# Altium schematic sheet SCM20260D-Dimm.SchDoc(SCM20260D-Dimm)
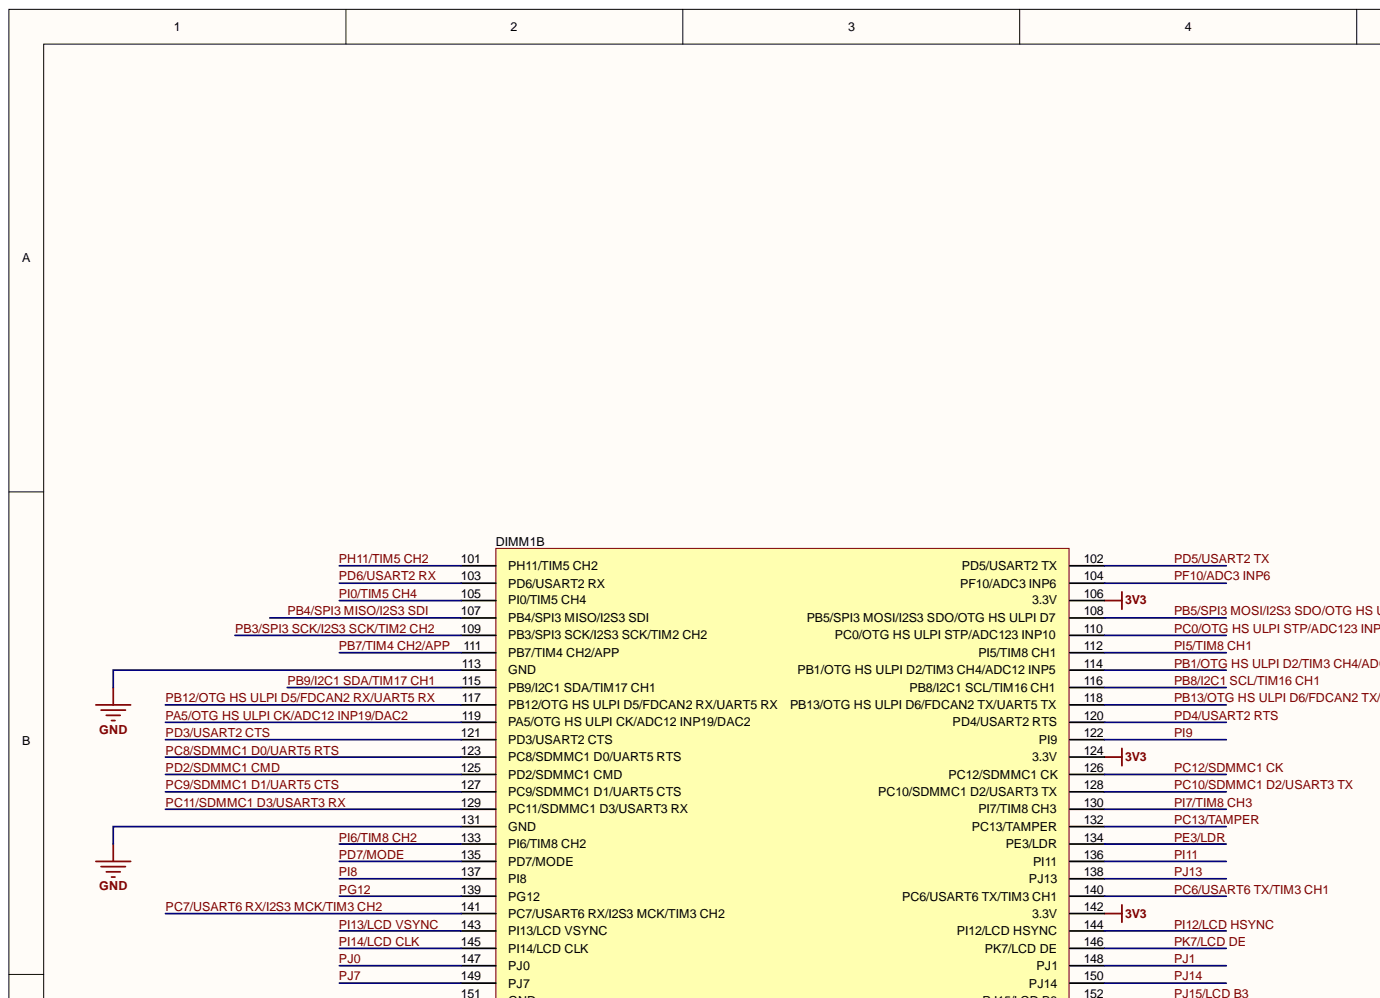
[diagram p0: SCM20260D-Dimm.SchDoc, top left]
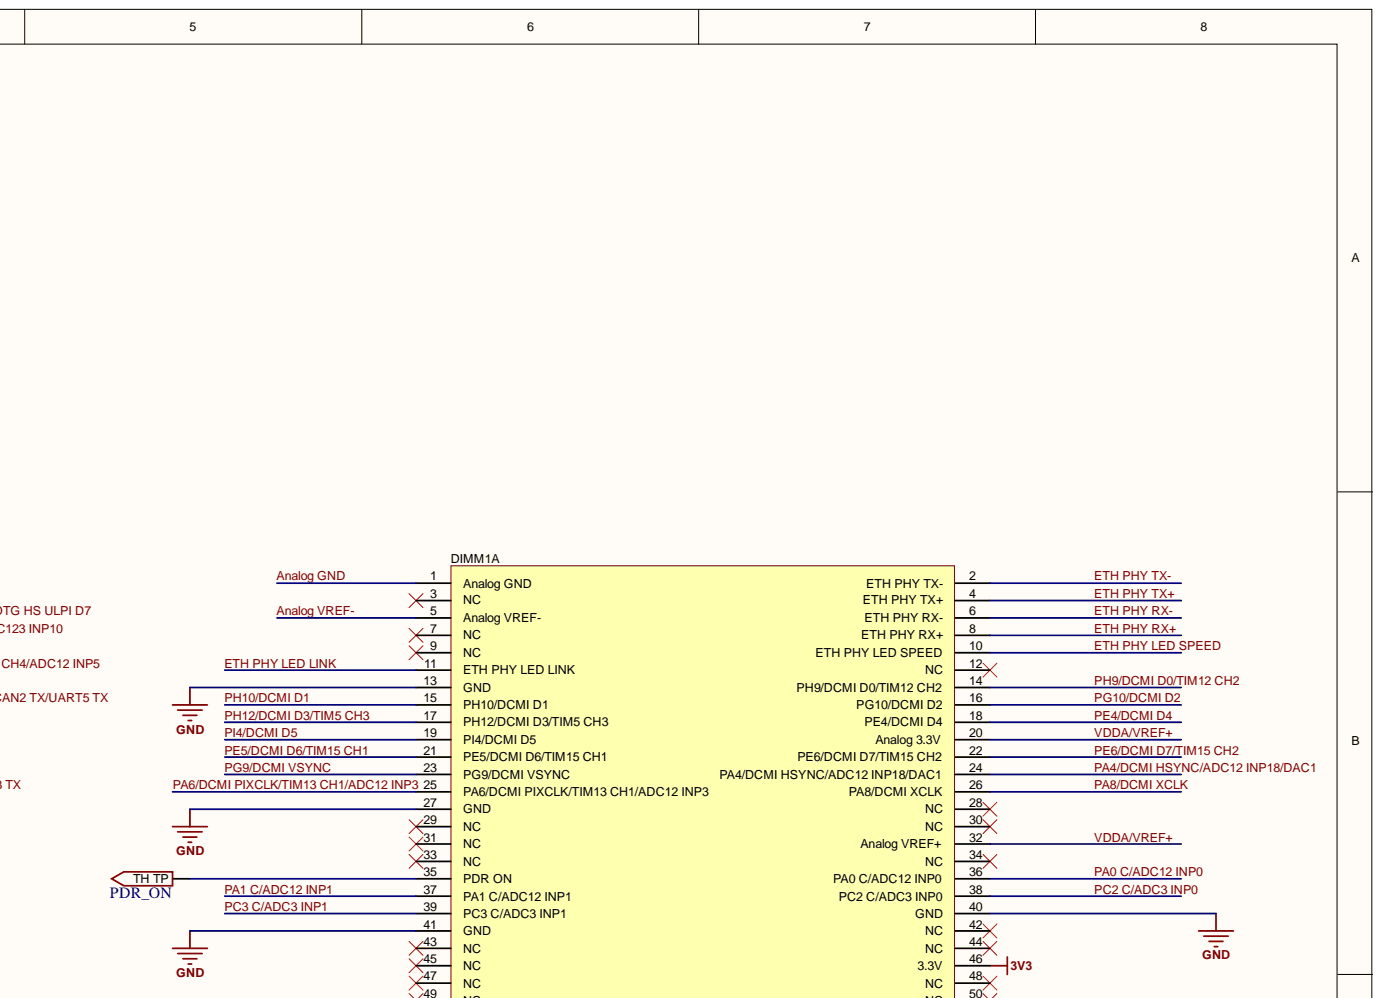
[diagram p1: SCM20260D-Dimm.SchDoc, top right]
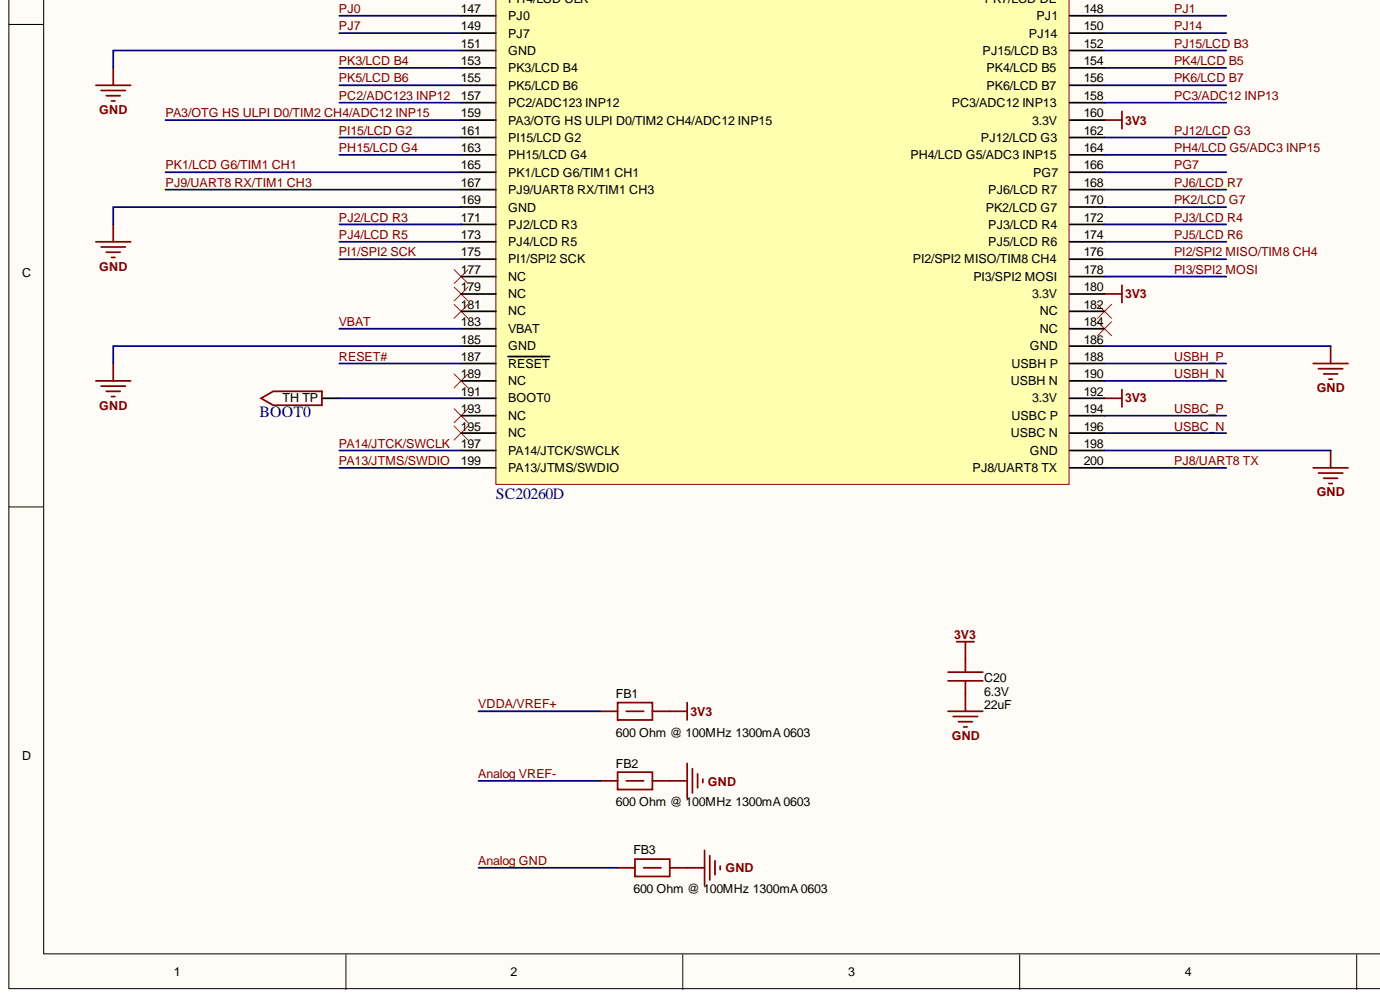
[diagram p2: SCM20260D-Dimm.SchDoc, bottom left]
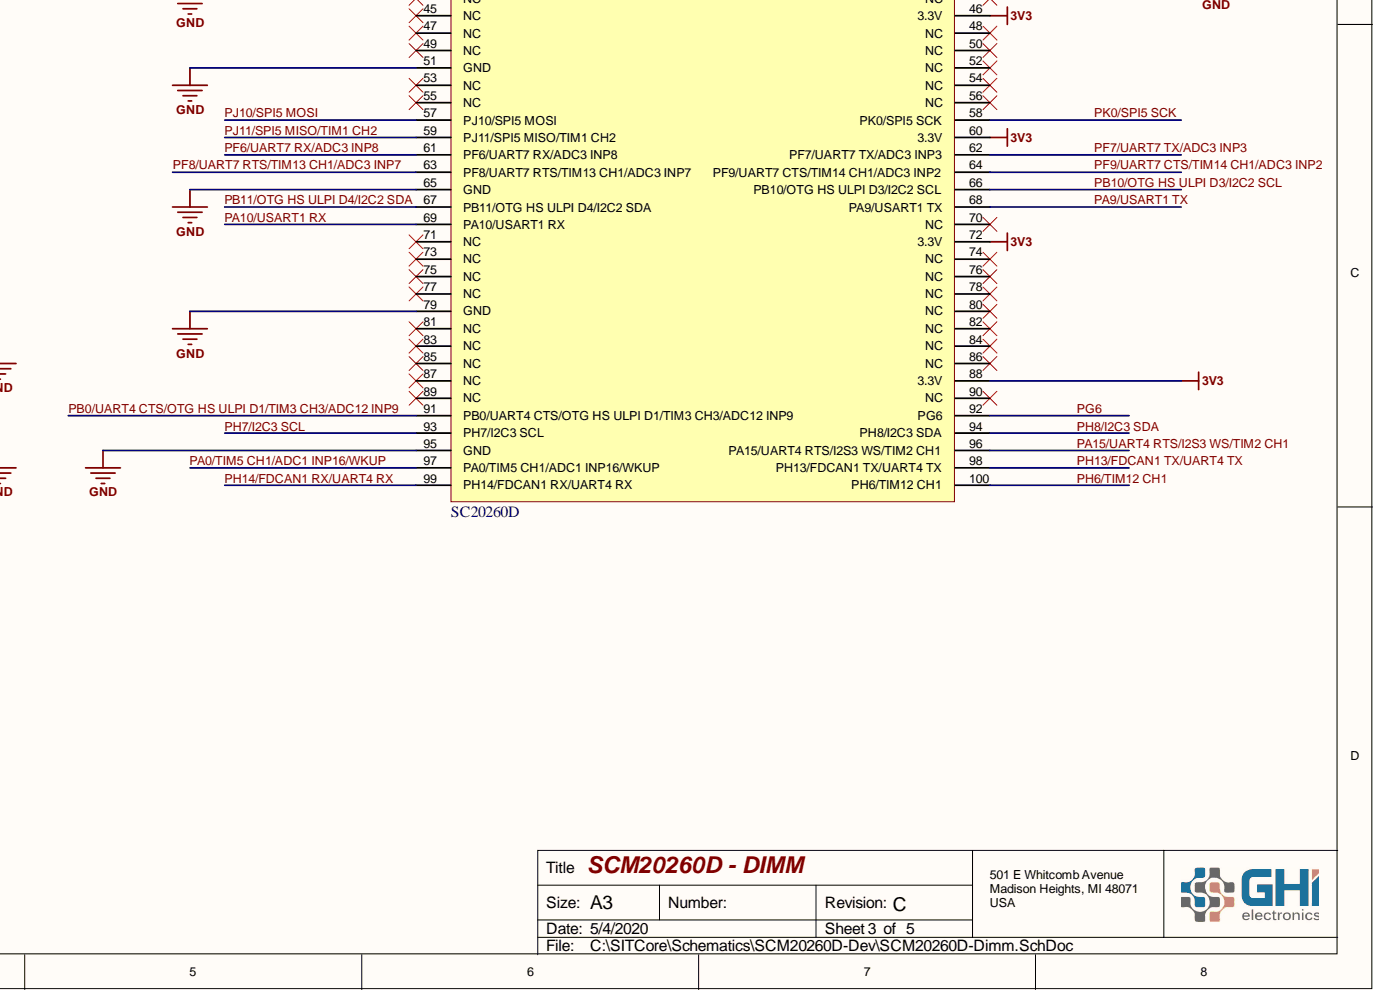
[diagram p3: SCM20260D-Dimm.SchDoc, bottom right]

## Parts
BOOT0  TH Testpad 32mil (32mil ar)  [32mil TH]  (value not drawn)  (no BOM)
C20  value 22uF  Voltage: 6.3V  [C0805]  (hidden comment: 0805 Cap 22uF)
DIMM1  SC20260D  [200 Pin 0.6mm pitch SO-DIMM]  (no BOM)  (parts A,B)
FB1  600 Ohm @ 100MHz 1300mA 0603  [R0603 (Metric 1608)]
FB2  600 Ohm @ 100MHz 1300mA 0603  [R0603 (Metric 1608)]
FB3  600 Ohm @ 100MHz 1300mA 0603  [R0603 (Metric 1608)]
PDR_ON  TH Testpad 32mil (32mil ar)  [32mil TH]  (value not drawn)  (no BOM)

## Nets
3V3: C20.2 DIMM1.46(3.3V) DIMM1.60(3.3V) DIMM1.72(3.3V) DIMM1.88(3.3V) DIMM1.106(3.3V) DIMM1.124(3.3V) DIMM1.142(3.3V) DIMM1.160(3.3V) DIMM1.180(3.3V) DIMM1.192(3.3V) FB1.2
GND: C20.1 DIMM1.13(GND) DIMM1.27(GND) DIMM1.40(GND) DIMM1.41(GND) DIMM1.51(GND) DIMM1.65(GND) DIMM1.79(GND) DIMM1.95(GND) DIMM1.113(GND) DIMM1.131(GND) DIMM1.151(GND) DIMM1.169(GND) DIMM1.185(GND) DIMM1.186(GND) DIMM1.198(GND) FB2.2 FB3.2
Analog GND: DIMM1.1(Analog_GND) FB3.1
Analog VREF-: DIMM1.5(Analog_VREF-) FB2.1
ETH PHY LED LINK: DIMM1.11(ETH_PHY_LED_LINK)
ETH PHY LED SPEED: DIMM1.10(ETH_PHY_LED_SPEED)
ETH PHY RX+: DIMM1.8(ETH_PHY_RX+)
ETH PHY RX-: DIMM1.6(ETH_PHY_RX-)
ETH PHY TX+: DIMM1.4(ETH_PHY_TX+)
ETH PHY TX-: DIMM1.2(ETH_PHY_TX-)
PA0 C/ADC12 INP0: DIMM1.36(PA0_C/ADC12_INP0)
PA0/TIM5 CH1/ADC1 INP16/WKUP: DIMM1.97(PA0/TIM5_CH1/ADC1_INP16/WKUP)
PA1 C/ADC12 INP1: DIMM1.37(PA1_C/ADC12_INP1)
PA3/OTG HS ULPI D0/TIM2 CH4/ADC12 INP15: DIMM1.159(PA3/OTG_HS_ULPI_D0/TIM2_CH4/ADC12_INP15)
PA4/DCMI HSYNC/ADC12 INP18/DAC1: DIMM1.24(PA4/DCMI_HSYNC/ADC12_INP18/DAC1)
PA5/OTG HS ULPI CK/ADC12 INP19/DAC2: DIMM1.119(PA5/OTG_HS_ULPI_CK/ADC12_INP19/DAC2)
PA6/DCMI PIXCLK/TIM13 CH1/ADC12 INP3: DIMM1.25(PA6/DCMI_PIXCLK/TIM13_CH1/ADC12_INP3)
PA8/DCMI XCLK: DIMM1.26(PA8/DCMI_XCLK)
PA9/USART1 TX: DIMM1.68(PA9/USART1_TX)
PA10/USART1 RX: DIMM1.69(PA10/USART1_RX)
PA13/JTMS/SWDIO: DIMM1.199(PA13/JTMS/SWDIO)
PA14/JTCK/SWCLK: DIMM1.197(PA14/JTCK/SWCLK)
PA15/UART4 RTS/I2S3 WS/TIM2 CH1: DIMM1.96(PA15/UART4_RTS/I2S3_WS/TIM2_CH1)
PB0/UART4 CTS/OTG HS ULPI D1/TIM3 CH3/ADC12 INP9: DIMM1.91
PB1/OTG HS ULPI D2/TIM3 CH4/ADC12 INP5: DIMM1.114(PB1/OTG_HS_ULPI_D2/TIM3_CH4/ADC12_INP5)
PB3/SPI3 SCK/I2S3 SCK/TIM2 CH2: DIMM1.109(PB3/SPI3_SCK/I2S3_SCK/TIM2_CH2)
PB4/SPI3 MISO/I2S3 SDI: DIMM1.107(PB4/SPI3_MISO/I2S3_SDI)
PB5/SPI3 MOSI/I2S3 SDO/OTG HS ULPI D7: DIMM1.108(PB5/SPI3_MOSI/I2S3_SDO/OTG_HS_ULPI_D7)
PB7/TIM4 CH2/APP: DIMM1.111(PB7/TIM4_CH2/APP)
PB8/I2C1 SCL/TIM16 CH1: DIMM1.116(PB8/I2C1_SCL/TIM16_CH1)
PB9/I2C1 SDA/TIM17 CH1: DIMM1.115(PB9/I2C1_SDA/TIM17_CH1)
PB10/OTG HS ULPI D3/I2C2 SCL: DIMM1.66(PB10/OTG_HS_ULPI_D3/I2C2_SCL)
PB11/OTG HS ULPI D4/I2C2 SDA: DIMM1.67(PB11/OTG_HS_ULPI_D4/I2C2_SDA)
PB12/OTG HS ULPI D5/FDCAN2 RX/UART5 RX: DIMM1.117(PB12/OTG_HS_ULPI_D5/FDCAN2_RX/UART5_RX)
PB13/OTG HS ULPI D6/FDCAN2 TX/UART5 TX: DIMM1.118(PB13/OTG_HS_ULPI_D6/FDCAN2_TX/UART5_TX)
PC0/OTG HS ULPI STP/ADC123 INP10: DIMM1.110(PC0/OTG_HS_ULPI_STP/ADC123_INP10)
PC2 C/ADC3 INP0: DIMM1.38(PC2_C/ADC3_INP0)
PC2/ADC123 INP12: DIMM1.157(PC2/ADC123_INP12)
PC3 C/ADC3 INP1: DIMM1.39(PC3_C/ADC3_INP1)
PC3/ADC12 INP13: DIMM1.158(PC3/ADC12_INP13)
PC6/USART6 TX/TIM3 CH1: DIMM1.140(PC6/USART6_TX/TIM3_CH1)
PC7/USART6 RX/I2S3 MCK/TIM3 CH2: DIMM1.141(PC7/USART6_RX/I2S3_MCK/TIM3_CH2)
PC8/SDMMC1 D0/UART5 RTS: DIMM1.123(PC8/SDMMC1_D0/UART5_RTS)
PC9/SDMMC1 D1/UART5 CTS: DIMM1.127(PC9/SDMMC1_D1/UART5_CTS)
PC10/SDMMC1 D2/USART3 TX: DIMM1.128(PC10/SDMMC1_D2/USART3_TX)
PC11/SDMMC1 D3/USART3 RX: DIMM1.129(PC11/SDMMC1_D3/USART3_RX)
PC12/SDMMC1 CK: DIMM1.126(PC12/SDMMC1_CK)
PC13/TAMPER: DIMM1.132(PC13/TAMPER)
PD2/SDMMC1 CMD: DIMM1.125(PD2/SDMMC1_CMD)
PD3/USART2 CTS: DIMM1.121(PD3/USART2_CTS)
PD4/USART2 RTS: DIMM1.120(PD4/USART2_RTS)
PD5/USART2 TX: DIMM1.102(PD5/USART2_TX)
PD6/USART2 RX: DIMM1.103(PD6/USART2_RX)
PD7/MODE: DIMM1.135(PD7/MODE)
PE3/LDR: DIMM1.134(PE3/LDR)
PE4/DCMI D4: DIMM1.18(PE4/DCMI_D4)
PE5/DCMI D6/TIM15 CH1: DIMM1.21(PE5/DCMI_D6/TIM15_CH1)
PE6/DCMI D7/TIM15 CH2: DIMM1.22(PE6/DCMI_D7/TIM15_CH2)
PF6/UART7 RX/ADC3 INP8: DIMM1.61(PF6/UART7_RX/ADC3_INP8)
PF7/UART7 TX/ADC3 INP3: DIMM1.62(PF7/UART7_TX/ADC3_INP3)
PF8/UART7 RTS/TIM13 CH1/ADC3 INP7: DIMM1.63(PF8/UART7_RTS/TIM13_CH1/ADC3_INP7)
PF9/UART7 CTS/TIM14 CH1/ADC3 INP2: DIMM1.64(PF9/UART7_CTS/TIM14_CH1/ADC3_INP2)
PF10/ADC3 INP6: DIMM1.104(PF10/ADC3_INP6)
PG6: DIMM1.92(PG6)
PG7: DIMM1.166(PG7)
PG9/DCMI VSYNC: DIMM1.23(PG9/DCMI_VSYNC)
PG10/DCMI D2: DIMM1.16(PG10/DCMI_D2)
PG12: DIMM1.139(PG12)
PH4/LCD G5/ADC3 INP15: DIMM1.164(PH4/LCD_G5/ADC3_INP15)
PH6/TIM12 CH1: DIMM1.100(PH6/TIM12_CH1)
PH7/I2C3 SCL: DIMM1.93(PH7/I2C3_SCL)
PH8/I2C3 SDA: DIMM1.94(PH8/I2C3_SDA)
PH9/DCMI D0/TIM12 CH2: DIMM1.14(PH9/DCMI_D0/TIM12_CH2)
PH10/DCMI D1: DIMM1.15(PH10/DCMI_D1)
PH11/TIM5 CH2: DIMM1.101(PH11/TIM5_CH2)
PH12/DCMI D3/TIM5 CH3: DIMM1.17(PH12/DCMI_D3/TIM5_CH3)
PH13/FDCAN1 TX/UART4 TX: DIMM1.98(PH13/FDCAN1_TX/UART4_TX)
PH14/FDCAN1 RX/UART4 RX: DIMM1.99(PH14/FDCAN1_RX/UART4_RX)
PH15/LCD G4: DIMM1.163(PH15/LCD_G4)
PI0/TIM5 CH4: DIMM1.105(PI0/TIM5_CH4)
PI1/SPI2 SCK: DIMM1.175(PI1/SPI2_SCK)
PI2/SPI2 MISO/TIM8 CH4: DIMM1.176(PI2/SPI2_MISO/TIM8_CH4)
PI3/SPI2 MOSI: DIMM1.178(PI3/SPI2_MOSI)
PI4/DCMI D5: DIMM1.19(PI4/DCMI_D5)
PI5/TIM8 CH1: DIMM1.112(PI5/TIM8_CH1)
PI6/TIM8 CH2: DIMM1.133(PI6/TIM8_CH2)
PI7/TIM8 CH3: DIMM1.130(PI7/TIM8_CH3)
PI8: DIMM1.137(PI8)
PI9: DIMM1.122(PI9)
PI11: DIMM1.136(PI11)
PI12/LCD HSYNC: DIMM1.144(PI12/LCD_HSYNC)
PI13/LCD VSYNC: DIMM1.143(PI13/LCD_VSYNC)
PI14/LCD CLK: DIMM1.145(PI14/LCD_CLK)
PI15/LCD G2: DIMM1.161(PI15/LCD_G2)
PJ0: DIMM1.147(PJ0)
PJ1: DIMM1.148(PJ1)
PJ2/LCD R3: DIMM1.171(PJ2/LCD_R3)
PJ3/LCD R4: DIMM1.172(PJ3/LCD_R4)
PJ4/LCD R5: DIMM1.173(PJ4/LCD_R5)
PJ5/LCD R6: DIMM1.174(PJ5/LCD_R6)
PJ6/LCD R7: DIMM1.168(PJ6/LCD_R7)
PJ7: DIMM1.149(PJ7)
PJ8/UART8 TX: DIMM1.200(PJ8/UART8_TX)
PJ9/UART8 RX/TIM1 CH3: DIMM1.167(PJ9/UART8_RX/TIM1_CH3)
PJ10/SPI5 MOSI: DIMM1.57(PJ10/SPI5_MOSI)
PJ11/SPI5 MISO/TIM1 CH2: DIMM1.59(PJ11/SPI5_MISO/TIM1_CH2)
PJ12/LCD G3: DIMM1.162(PJ12/LCD_G3)
PJ13: DIMM1.138(PJ13)
PJ14: DIMM1.150(PJ14)
PJ15/LCD B3: DIMM1.152(PJ15/LCD_B3)
PK0/SPI5 SCK: DIMM1.58(PK0/SPI5_SCK)
PK1/LCD G6/TIM1 CH1: DIMM1.165(PK1/LCD_G6/TIM1_CH1)
PK2/LCD G7: DIMM1.170(PK2/LCD_G7)
PK3/LCD B4: DIMM1.153(PK3/LCD_B4)
PK4/LCD B5: DIMM1.154(PK4/LCD_B5)
PK5/LCD B6: DIMM1.155(PK5/LCD_B6)
PK6/LCD B7: DIMM1.156(PK6/LCD_B7)
PK7/LCD DE: DIMM1.146(PK7/LCD_DE)
RESET#: DIMM1.187(RESET)
USBC_N: DIMM1.196(USBC_N)
USBC_P: DIMM1.194(USBC_P)
USBH_N: DIMM1.190(USBH_N)
USBH_P: DIMM1.188(USBH_P)
VBAT: DIMM1.183(VBAT)
VDDA/VREF+: DIMM1.20(Analog_3.3V) DIMM1.32(Analog_VREF+) FB1.1
NetBOOT0_1: BOOT0.1 DIMM1.191(BOOT0)
NetDIMM1_35: DIMM1.35(PDR_ON) PDR_ON.1

Not connected: DIMM1 3(NC) 7(NC) 9(NC) 12(NC) 28(NC) 29(NC) 30(NC) 31(NC) 33(NC) 34(NC) 42(NC) 43(NC) 44(NC) 45(NC) 47(NC) 48(NC) 49(NC) 50(NC) 52(NC) 53(NC) 54(NC) 55(NC) 56(NC) 70(NC) 71(NC) 73(NC) 74(NC) 75(NC) 76(NC) 77(NC) 78(NC) 80(NC) 81(NC) 82(NC) 83(NC) 84(NC) 85(NC) 86(NC) 87(NC) 89(NC) 90(NC) 177(NC) 179(NC) 181(NC) 182(NC) 184(NC) 189(NC) 193(NC) 195(NC)

## Library data of the parts
BOOT0 PDR_ON = TH Testpad 32mil (32mil ar): TH Testpad 32mil (32mil annular ring); Library Name: GHI.SchLib
C20 = 0805 Cap 22uF: 22uF ±20% 6.3V X5R 0805 Multilayer Ceramic Capacitors MLCC - SMD/SMT RoHS; Mfr.Part #: CL21A226MQCLRNC; Tolerance: ±20%; Package: 0805; LCSC Part #: C307530; Component Kind: Standard; Category: Ceramic; Capacitance: 22uF; Library Name: GHI-Passives.SchLib
DIMM1 = SC20260D: SC20260D DIMM Module Schematic Symbol; ClassName: Edge Connector; Library Name: GHI.SchLib
FB1-FB3 = 600 Ohm @ 100MHz 1300mA 0603 (Metric 1608): Ferrite Bead 600 Ohm @ 100MHz 1300mA 0603 (Metric 1608); GHI Part Number: x; Value: BLM18KG601SN1D; Rated Current (mA @ 125C): 1000; Rated Current (mA @ 85C): 1300; Impedance @ 100MHz: 600+-25%; DC Resistance: 150 mOhm; PartNo: BLM18KG601SN1D; Package: 0603; Library Name: GHI Basic Components.SchLib; Component Type: Ferrite Bead; Component Kind: Standard
